# Altium schematic sheet Other_Part.SchDoc(Other_Part)
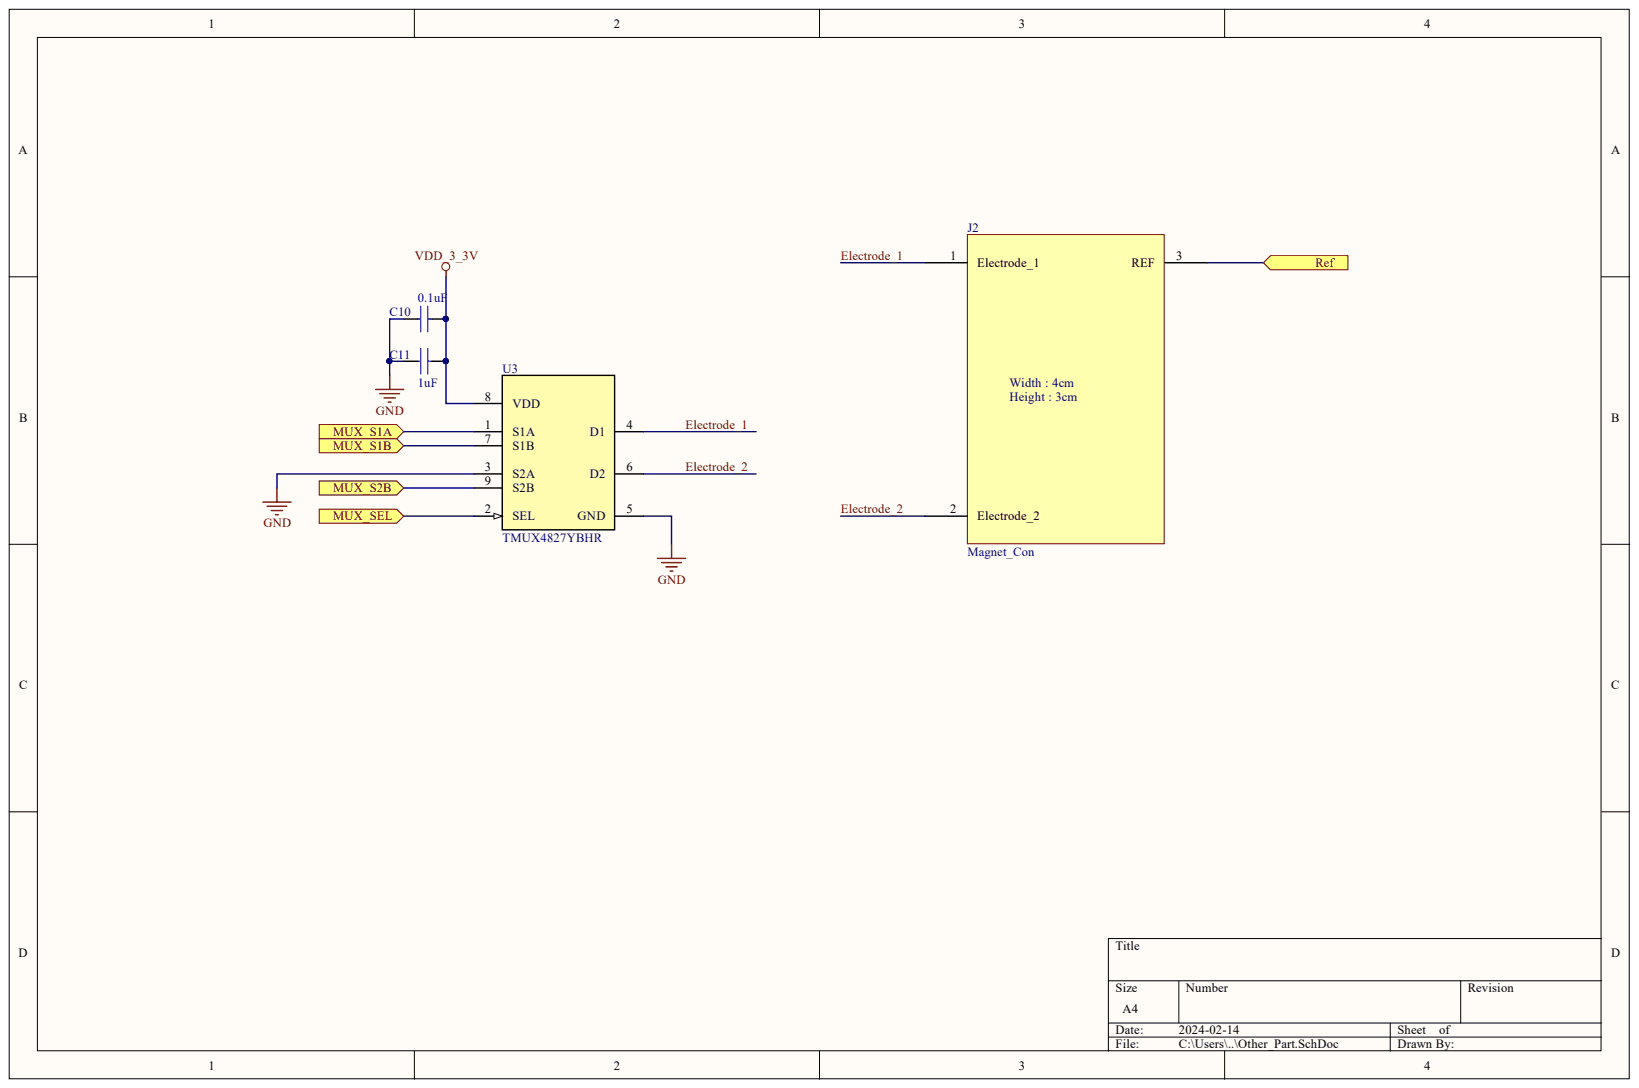
[diagram p0: Other_Part.SchDoc]

## Parts
C10  0.1uF  [C1608]
C11  1uF  [C1608]
J2  Magnet_Con  [BRUXISM_MAGNET_CON]
U3  TMUX4827YBHR  [YBH0009-C02-IPC_A]

## Ports
MUX_S1A, MUX_S1B, MUX_S2B, MUX_SEL, REF

## Nets
GND: C10.1 C11.1 U3.3(S2A) U3.5(GND)
VDD_3_3V: C10.2 C11.2 U3.8(VDD)
ELECTRODE_1: J2.1 U3.4(D1)
ELECTRODE_2: J2.2 U3.6(D2)
MUX_S1A: U3.1(S1A) ; port MUX_S1A
MUX_S1B: U3.7(S1B) ; port MUX_S1B
MUX_S2B: U3.9(S2B) ; port MUX_S2B
MUX_SEL: U3.2(SEL) ; port MUX_SEL
NetJ2_3: J2.3 ; port REF

## Library data of the parts
C10 C11 = Capacitor: Component Kind: Standard; Description: Capacitor; Library Name: Dong_Parts.IntLib
U3 = TMUX4827YBHR: No Description Available; Mfr_Name: Texas Instruments; Component Kind: Standard; Manufacturer_Part_Number: TMUX4827YBHR; RefDes: RefDes; Type: DEV; Copyright: Copyright (C) 2024 Ultra Librarian. All rights reserved.; Library Name: Dong_Parts.IntLib
J2 = Magnet_Con: Component Kind: Standard; Library Name: Dong_Connectors.SchLib
